# Altium schematic sheet Step-up DC-DC converter.SchDoc("Step-up DC-DC converter")
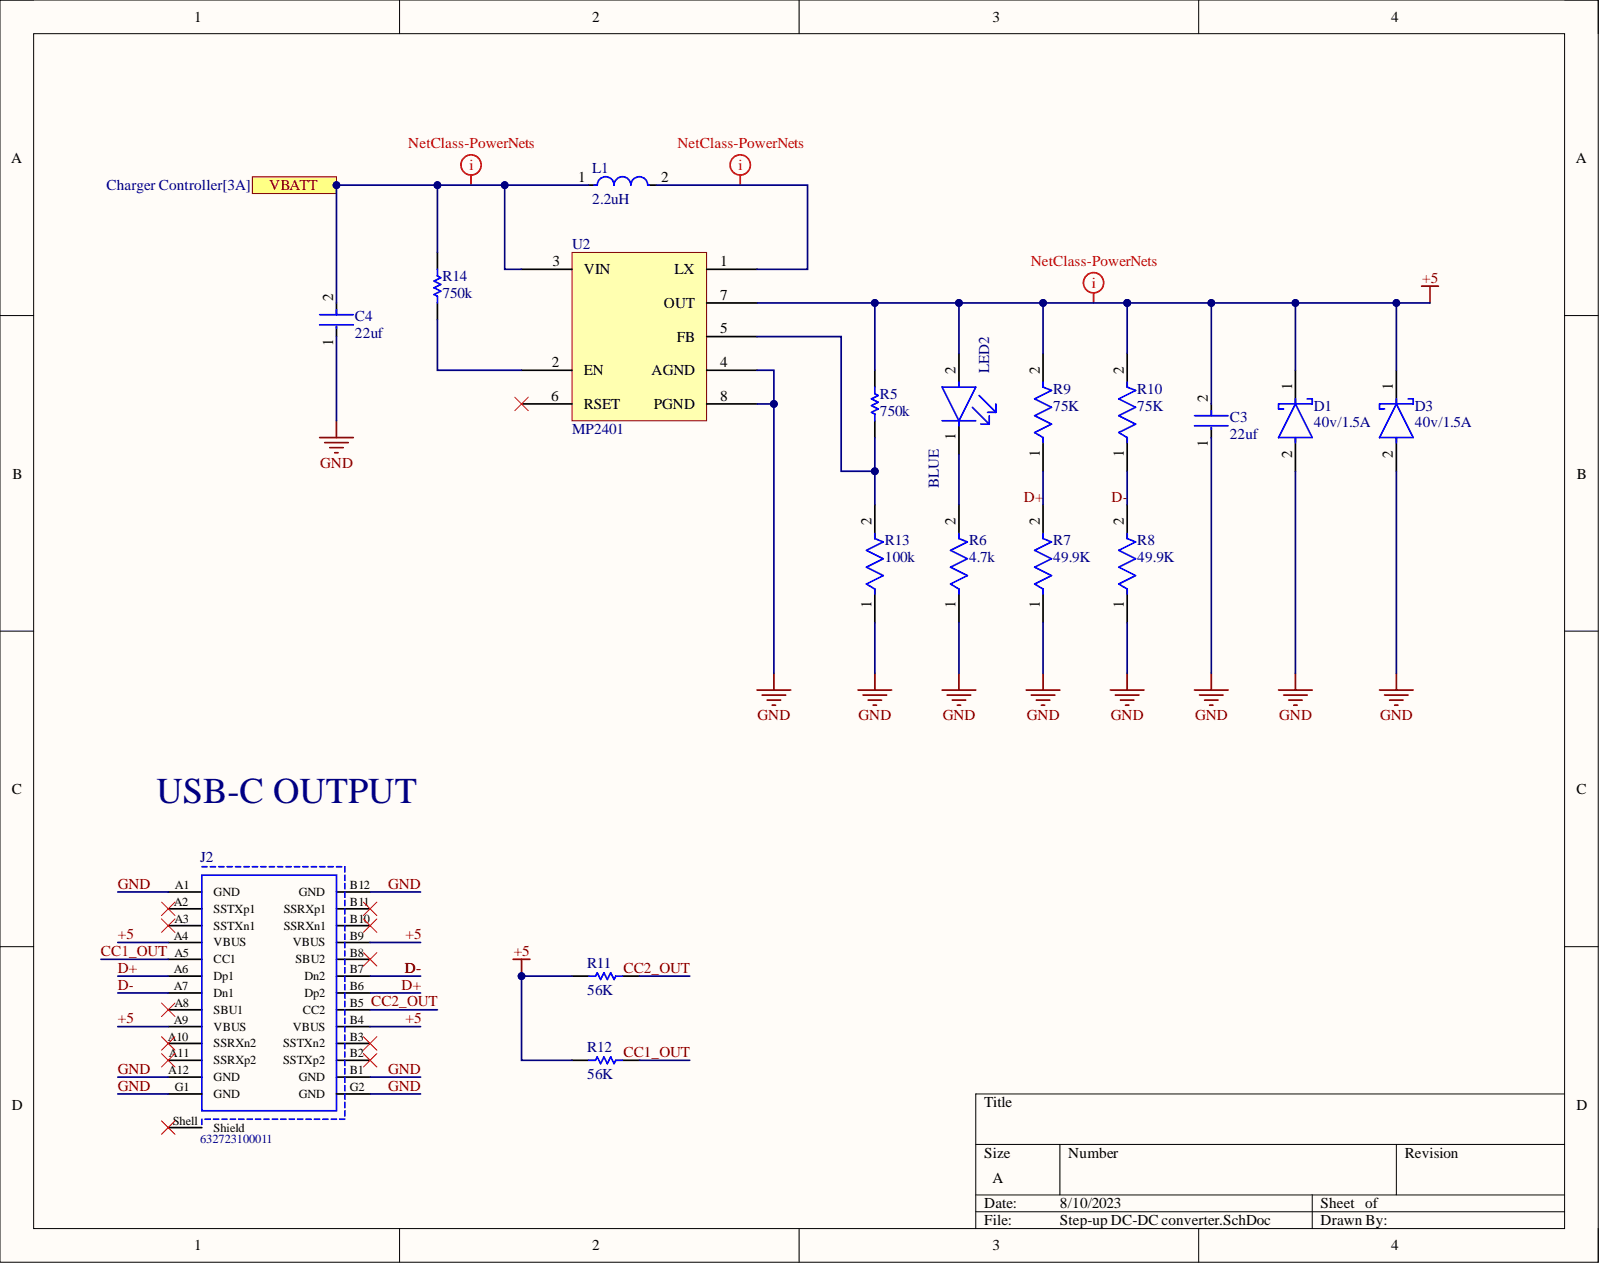
[diagram p0: Step-up DC-DC converter.SchDoc]

## Parts
C3  22uf
C4  22uf
D1  40v/1.5A
D3  40v/1.5A
J2  632723100011
L1  2.2uH
LED2  BLUE
R5  750k
R6  4.7k
R7  49.9K
R8  49.9K
R9  75K
R10  75K
R11  56K
R12  56K
R13  100k
R14  750k
U2  MP2401

## Ports
VBATT

## Nets
+5: C3.2 D1.1 D3.1 J2.A4(VBUS) J2.A9(VBUS) J2.B4(VBUS) J2.B9(VBUS) LED2.2 R5.1 R9.2 R10.2 R11.1 R12.1 U2.7(OUT)
CC1_OUT: J2.A5(CC1) R12.2
CC2_OUT: J2.B5(CC2) R11.2
D+: J2.A6(Dp1) J2.B6(Dp2) R7.2 R9.1
D-: J2.A7(Dn1) J2.B7(Dn2) R8.2 R10.1
GND: C3.1 C4.1 D1.2 D3.2 J2.A1(GND) J2.A12(GND) J2.B1(GND) J2.B12(GND) J2.G1(GND) J2.G2(GND) R6.1 R7.1 R8.1 R13.1 U2.4(AGND) U2.8(PGND)
VBATT: C4.2 L1.1 R14.1 U2.3(VIN) ; port VBATT
NetL1_2: L1.2 U2.1(LX)
NetLED2_1: LED2.1 R6.2
NetR5_2: R5.2 R13.2 U2.5(FB)
NetR14_2: R14.2 U2.2(EN)

Not connected: J2 A2(SSTXp1) A3(SSTXn1) A8(SBU1) A10(SSRXn2) A11(SSRXp2) B2(SSTXp2) B3(SSTXn2) B8(SBU2) B10(SSRXn1) B11(SSRXp1) Shell(Shield); U2 6(RSET)

## Library data of the parts
C3 C4 = CMP-14477-000204-1: CAP CER 22UF 6.3V X5R 0805; Tolerance: 20%; Capacitance: 22uF; Case Code (Imperial): 0805; Case Code (Metric): 2012; Case/Package: 0805; Component Kind: Standard; Construction: Flat; Contact Plating: Nickel; Depth: 1.25mm; Dielectric: X5R; Height: 1.25mm; Lead Free: Lead Free; Length: 2mm; Library Name: Altium Content Vault; Max Operating Temperature: 85°C; Min Operating Temperature: -55°C; Mount: Surface Mount; Package Quantity: 1; Packaging: Tape and Reel; Radiation Hardening: No; Resistor Type: Ceramic; RoHS Compliant: Yes; Series: M; Termination: SMD/SMT; Thickness: 0.053inch; Voltage Rating: 6.3V; Voltage Rating (DC): 6.3V; Width: 0.049inch
D1 D3 = CMP-02539-000025-1: DIODE SCHOTTKY 40V 1.5A SMA; Manufacturer: Vishay; Manufacturer Part Number: VS-15MQ040NTRPBF; Capacitance: 134pF; Max Junction Temp: +150°C; Max Surge Forward Current: 140A; Peak Reverse Current: 0.5mA; Standoff Height: 0mm; Value: 134pF; Automotive: No; Category: Diode; Component Kind: Standard; ComponentLink2Description: Datasheet; ComponentLink2URL: http://www.vishay.com/docs/94141/vs-15mq040ntrpbf.pdf; Device Class L1: Discrete Semiconductors; Device Class L2: Diodes; Device Class L3: Schottky Diodes; Digikey Description: DIODE SCHOTTKY 40V 1.5A SMA; Footprint Url: http://www.vishay.com/docs/95400/sma.pdf; Forward Current: 1.5A; Height: 2.29mm; Lead Free: Yes; Library Name: Altium Content Vault; Max Repetitive Reverse Voltage: 40V; Mouser Description: Schottky Diodes & Rectifiers 2.1 Amp 40 Volt; Octopart: https://octopart.com/vs-15mq040ntrpbf-vishay-44340959; Package: 95400; Reverse Voltage: 40V; RoHS: TRUE; Temperature: -40°C to +150°C; Temperature Range High: +150°C; Temperature Range Low: -40°C
J2 = CMP-1502-03213-1: USB 3.1 Type C Receptacle WR-COM, Horizontal, SMT / THT; Manufacturer: Wurth Elektronik; Contact Resistance: 30mOhm; Rated Current: 5A; Working Voltage: 20VDC; Component Kind: Standard; Connector Type: USB3.1TypeC; Datasheet URL: https://katalog.we-online.de/em/datasheet/632723x00011%282%29.pdf; Gender: Receptacle; Library Name: Altium Content Vault; Manufacturer URL: http://www.we-online.de; Mounting Technology: SMT/THT; Operating Temperature: -40to105degC; Orientation: Horizontal; Package Reference: 632723100011; Packaging: TapeandReel; Part Number: 632723100011; Publisher: WurthElektronik
L1 = CMP-1662-00028-2: Multilayer Type Inductor for Power Lines, 1008 (2520), 2.2μH, 20%, 0.1Ω, 1.3A; Core Material: Ferrite; DC Resistance (DCR): 100mR; Self Resonant Frequency: 40MHz; Shielding: Shielded; Test Frequency: 1MHz; Case Code (Imperial): 1008; Case Code (Metric): 2520; Case/Package: 1008; Component Kind: Standard; Current Rating: 1.3A; DC Current: 1.3A; Depth: 2.2mm; Height: 1.2mm; Height - Seated (Max): 0.039inch; Inductance: 2.2uH; Inductance Tolerance: 20%; Lead Free: Lead Free; Length: 2.5mm; Library Name: Altium Content Vault; Max DC Current: 1.3A; Max Operating Temperature: 125°C; Military Standard: Not; Min Operating Temperature: -55°C; Mount: Surface Mount; Package Quantity: 3000; Packaging: Tape and Reel; Radiation Hardening: No; REACH SVHC: No SVHC; Series: LQM2HP_G0; Termination: SMD/SMT; Tolerance: 20%; Width: 2mm
LED2 = CMP-22017-000034-1: LED BLUE CLEAR CHIP SMD; Dominant Wavelength: 475nm; Forward Current: 20mA; Forward Voltage: 3.8V; Lens Color: Colorless; Lens Style: Diffused; Luminous Intensity: 180mcd; Number of Elements: 1; Power Dissipation: 76mW; Reverse Voltage: 5V; Viewing Angle: 130°; Wavelength: 468nm; Case Code (Imperial): 0805; Case Code (Metric): 2012; Case/Package: 0805; Color: Blue; Component Kind: Standard; Depth: 1.25mm; Height: 0.8mm; Illumination Color: Blue; Lead Free: Lead Free; Length: 2mm; Lens Transparency: Clear; Library Name: Altium Content Vault; Max Operating Temperature: 80°C; Min Operating Temperature: -20°C; Mount: Surface Mount; Number of LEDs: 1; Number of Pins: 2; Package Quantity: 1; Packaging: Tape and Reel; Radiation Hardening: No; Test Current: 20mA; Width: 1.25mm
R5 R14 = CMP-2001-04102-1: Manufacturer: Vishay Dale; Part Number: CRCW0805750KFKEA; Component Kind: Standard; Library Name: Altium Content Vault
R6 = CMP-2100-03615-2: RES Thick Film, 4.7kΩ, 1%, 0.125W, 100ppm/°C, 0805; Max Power Dissipation: 125mW; Resistance: 4.7kR; Voltage Rating: 150V; Case Code (Imperial): 0805; Case Code (Metric): 2012; Case/Package: 0805; Component Kind: Standard; Composition: Thick Film; Contact Plating: Tin; Depth: 1.25mm; Features: Automotive AEC-Q200; Height: 0.45mm; Lead Free: Lead Free; Length: 2mm; Library Name: Altium Content Vault; Max Operating Temperature: 155°C; Military Standard: Not; Min Operating Temperature: -55°C; Number of Terminations: 2; Package Quantity: 10; Packaging: Tape and Reel; Power Rating: 125mW; Radiation Hardening: No; Temperature Coefficient: 100ppm/°C; Termination: SMD/SMT; Tolerance: 1%; Voltage Rating (DC): 150V; Weight: 0.000194oz; Width: 1.25mm
R7 R8 = CMP-2001-03952-2: RES Thick Film, 49.9kΩ, 1%, 0.125W, 100ppm/°C, 0805; Max Power Dissipation: 125mW; Resistance: 49.9kR; Voltage Rating: 150V; Case Code (Imperial): 0805; Case Code (Metric): 2012; Case/Package: 0805; Component Kind: Standard; Composition: Thick Film; Contact Plating: Tin; Depth: 1.25mm; Features: Automotive AEC-Q200; Height: 0.45mm; Lead Free: Lead Free; Length: 2mm; Library Name: Altium Content Vault; Max Operating Temperature: 155°C; Military Standard: Not; Min Operating Temperature: -55°C; Mount: Surface Mount; Number of Terminations: 2; Package Quantity: 10; Packaging: Tape and Reel; Power Rating: 125mW; Radiation Hardening: No; Temperature Coefficient: 100ppm/°C; Termination: SMD/SMT; Tolerance: 1%; Voltage Rating (DC): 150V; Weight: 0.000194oz; Width: 0.049inch
R9 R10 = CMP-2001-04106-2: RES Thick Film, 75kΩ, 1%, 0.125W, 100ppm/°C, 0805; Max Power Dissipation: 125mW; Resistance: 75kR; Voltage Rating: 150V; Case Code (Imperial): 0805; Case Code (Metric): 2012; Case/Package: 0805; Component Kind: Standard; Construction: Rectangular; Contact Plating: Tin; Depth: 1.25mm; Features: Automotive AEC-Q200; Height: 0.45mm; Lead Free: Lead Free; Length: 2mm; Library Name: Altium Content Vault; Max Operating Temperature: 155°C; Military Standard: Not; Min Operating Temperature: -55°C; Mount: Surface Mount; Number of Pins: 2; Number of Terminations: 2; Package Quantity: 10; Packaging: Tape and Reel; Power Rating: 125mW; Radiation Hardening: No; Resistance Tolerance: 1%; Resistor Type: Thick Film; RoHS Compliant: Yes; Series: CRCW; Technology: THICK FILM; Temperature Coefficient: 100ppm/°C; Termination: SMD/SMT; Tolerance: 1%; Voltage Rating (DC): 150V; Weight: 0.000194oz; Width: 0.049inch; Working Voltage: 150V
R11 R12 = CMP-1012-00708-2: Manufacturer: Vishay Dale; Part Number: CRCW060356K0FKEA; Component Kind: Standard; Library Name: Altium Content Vault
R13 = CMP-2001-04899-2: RES Thick Film, 100kΩ, 1%, 0.125W, 100ppm/°C, 0805; Power Rating: 125mW; Resistance: 100kR; Voltage Rating: 150V; Case Code (Imperial): 0805; Case Code (Metric): 2012; Case/Package: 0805; Component Kind: Standard; Composition: Thick Film; Contact Plating: Tin; Depth: 1.25mm; Height: 0.45mm; Lead Free: Lead Free; Length: 2mm; Library Name: Altium Content Vault; Max Operating Temperature: 155°C; Military Standard: Not; Min Operating Temperature: -55°C; Mount: Surface Mount; Number of Pins: 2; Package Quantity: 5000; Packaging: Tape and Reel; Radiation Hardening: No; Temperature Coefficient: 100ppm/°C; Termination: SMD/SMT; Tolerance: 1%; Voltage Rating (DC): 150V; Weight: 0.000194oz; Width: 1.25mm
U2 = MP2401: Component Kind: Standard; Library Name: Custom.SchLib
